# Altium schematic sheet Sheet4.SchDoc(Sheet4)
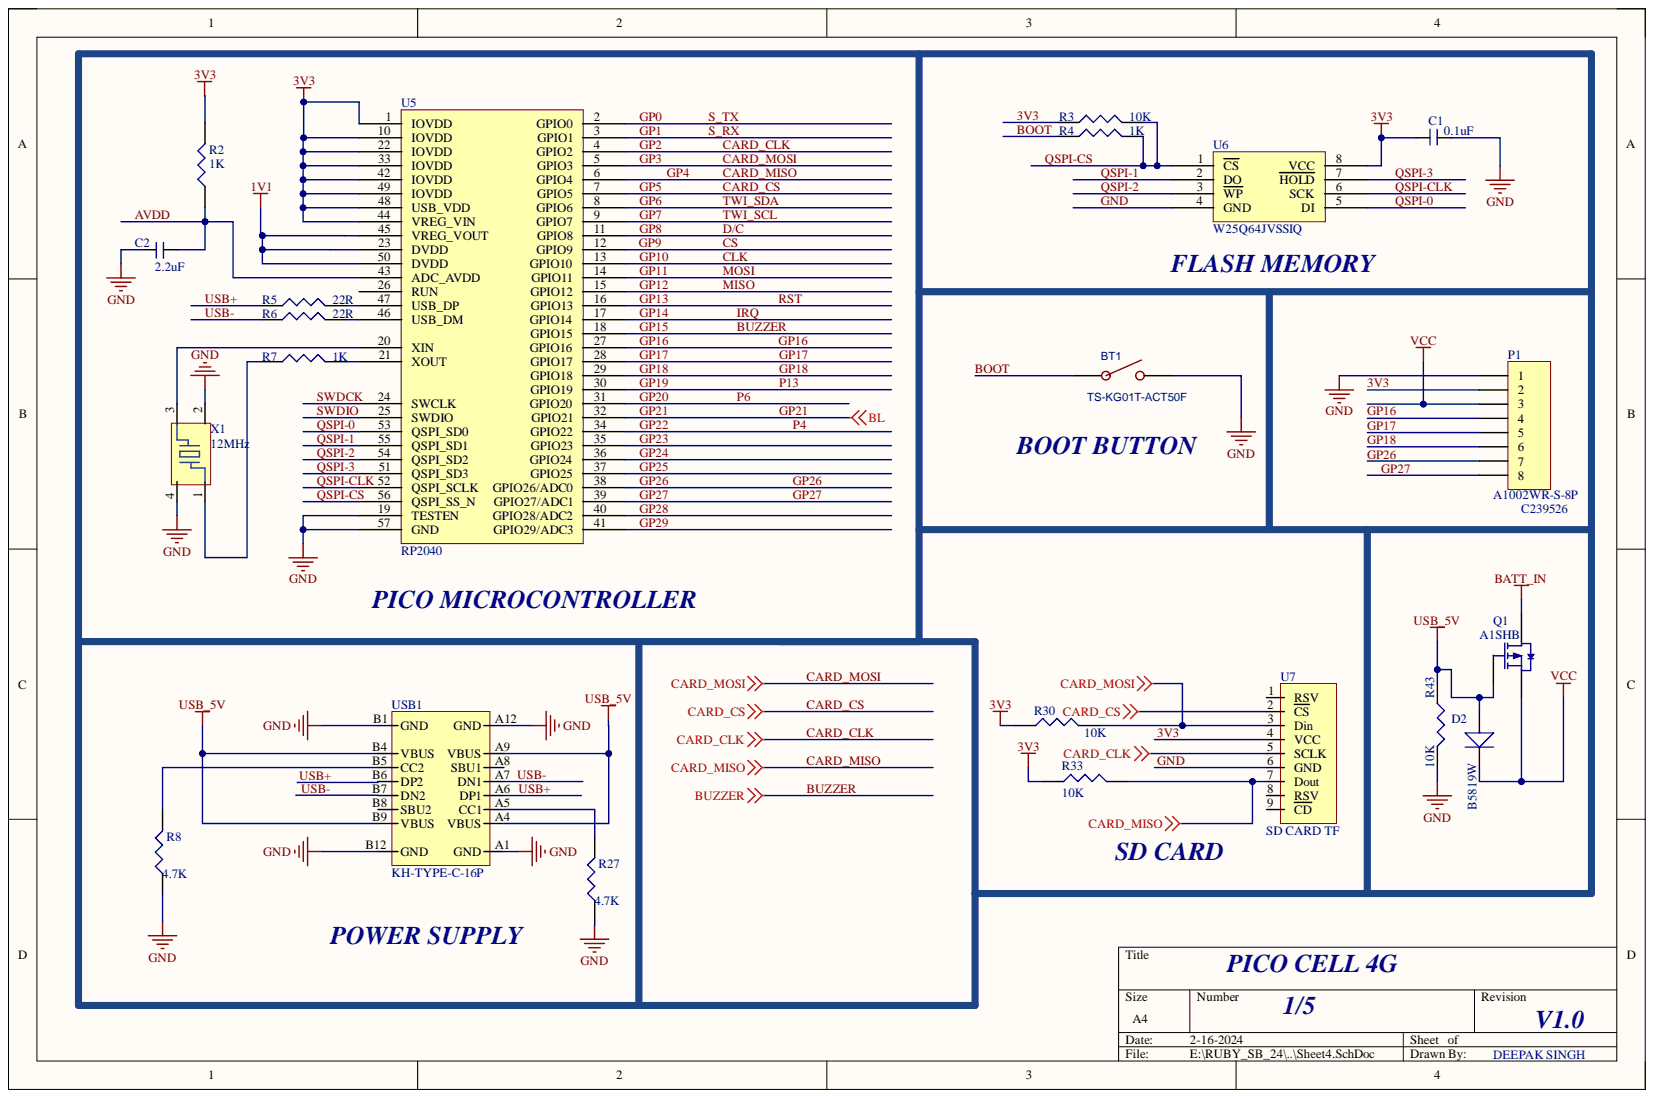
[diagram p0: Sheet4.SchDoc]

## Parts
BT1  TS-KG01T-ACT50F  [SW_2P SMD]
C1  0.1uF  [C_0603]
C2  2.2uF  [C_0603]
D2  B5819W  [SOD-123]
P1  A1002WR-S-8P  [SH 1X8P 1MM]
Q1  A1SHB  [SOT23-3]
R2  1K  [R_0603]
R3  10K  [R_0603]
R4  1K  [R_0603]
R5  22R  [R_0603]
R6  22R  [R_0603]
R7  1K  [R_0603]
R8  4.7K  [R_0603]
R27  4.7K  [R_0603]
R30  10K  [R_0603]
R33  10K  [R_0603]
R43  10K  [R_0603]
U5  RP2040  [QFN56]
U6  W25Q64JVSSIQ  [SOIC-8]
U7  SD CARD TF  [micro sd card]
USB1  KH-TYPE-C-16P  [USB TYPE C]
X1  12MHz  [crystal]

## Nets
1V1: U5.23(DVDD) U5.45(VREG_VOUT) U5.50(DVDD)
BATT_IN: Q1.3
USB_5V: D2.A Q1.1 R43.1 USB1.A4(VBUS) USB1.A9(VBUS) USB1.B4(VBUS) USB1.B9(VBUS)
VCC: D2.K P1.3 Q1.2
3V3: C1.2 P1.2 R2.2 R3.1 R30.1 R33.1 U5.1(IOVDD) U5.10(IOVDD) U5.22(IOVDD) U5.33(IOVDD) U5.42(IOVDD) U5.44(VREG_VIN) U5.48(USB_VDD) U5.49(IOVDD) U6.8(VCC) U7.4(VCC)
AVDD: C2.2 R2.1 U5.43(ADC_AVDD)
BOOT: BT1.1 R4.1
BUZZER: U5.18(GPIO15)
CARD_CLK: U5.4(GPIO2) U7.5(SCLK)
CARD_CS: U5.7(GPIO5) U7.2(CS)
CARD_MISO: R33.2 U5.6(GPIO4) U7.7(Dout)
CARD_MOSI: R30.2 U5.5(GPIO3) U7.3(Din)
CLK: U5.13(GPIO10)
CS: U5.12(GPIO9)
D/C: U5.11(GPIO8)
GND: BT1.2 C1.1 C2.1 P1.1 R8.1 R27.1 R43.2 U5.19(TESTEN) U5.57(GND) U6.4(GND) U7.6(GND) USB1.A1(GND) USB1.A12(GND) USB1.B1(GND) USB1.B12(GND) X1.2 X1.4
GP0: U5.2(GPIO0)
GP1: U5.3(GPIO1)
GP6: U5.8(GPIO6)
GP7: U5.9(GPIO7)
GP11: U5.14(GPIO11)
GP12: U5.15(GPIO12)
GP13: U5.16(GPIO13)
GP14: U5.17(GPIO14)
GP16: P1.4 U5.27(GPIO16)
GP17: P1.5 U5.28(GPIO17)
GP18: P1.6 U5.29(GPIO18)
GP19: U5.30(GPIO19)
GP20: U5.31(GPIO20)
GP21: U5.32(GPIO21)
GP22: U5.34(GPIO22)
GP23: U5.35(GPIO23)
GP24: U5.36(GPIO24)
GP25: U5.37(GPIO25)
GP26: P1.7 U5.38(GPIO26/ADC0)
GP27: P1.8 U5.39(GPIO27/ADC1)
GP28: U5.40(GPIO28/ADC2)
GP29: U5.41(GPIO29/ADC3)
QSPI-0: U5.53(QSPI_SD0) U6.5(DI)
QSPI-1: U5.55(QSPI_SD1) U6.2(DO)
QSPI-2: U5.54(QSPI_SD2) U6.3(WP)
QSPI-3: U5.51(QSPI_SD3) U6.7(HOLD)
QSPI-CLK: U5.52(QSPI_SCLK) U6.6(SCK)
QSPI-CS: R3.2 R4.2 U5.56(QSPI_SS_N) U6.1(CS)
SWDCK: U5.24(SWCLK)
SWDIO: U5.25(SWDIO)
USB+: R5.1 USB1.A6(DP1) USB1.B6(DP2)
USB-: R6.1 USB1.A7(DN1) USB1.B7(DN2)
NetR5_2: R5.2 U5.47(USB_DP)
NetR6_2: R6.2 U5.46(USB_DM)
NetR7_1: R7.1 X1.1
NetR7_2: R7.2 U5.21(XOUT)
NetR8_2: R8.2 USB1.B5(CC2)
NetR27_2: R27.2 USB1.A5(CC1)
NetU5_20: U5.20(XIN) X1.3

Not connected: U5 26(RUN); U7 1(RSV) 8(RSV) 9(CD); USB1 A8(SBU1) B8(SBU2)

## Library data of the parts
BT1 = JT1185SAEM: BOM_Manufacturer: NAMAE(韩国南涯); Contributor: LCSC; BOM_JLCPCB Part Class: Extended Part; BOM_Manufacturer Part: JT1185SAEM; BOM_Supplier: LCSC; BOM_Supplier Part: C465068; Component Kind: Standard; spicePre: S; spiceSymbolName: JT1185SAEM
C1 C2 = CAP_NONPOLAR: Component Kind: Standard; Library Name: dxp_pasl_lib.IntLib
D2 = DIODE: Component Kind: Standard; Library Name: dxp_pasl_lib.IntLib
P1 = Header 8: Header, 8-Pin; Component Kind: Standard; LatestRevisionDate: 17-Jul-2002; LatestRevisionNote: Re-released for DXP Platform.; Library Name: Miscellaneous Connectors.IntLib; Signal Integrity: Connector; Publisher: Altium Limited
Q1 = BSS84: 50V 130mA 250mW 10Ω 10V,130mA 2V 1mA P Channel SOT-23(SOT-23-3) MOSFETs ROHS #C493579; PackageDocument: 1995/1996; CATEGORY: MOSFET; Component Kind: Standard; LatestRevisionDate: 17-Jul-2002; LatestRevisionNote: Re-released for DXP Platform.; PackageReference: E3; Published: 8-Jun-2000; Library Name: dxp_pasl_lib.IntLib; Publisher: Altium Limited
R2-R8 R27 R30 R33 R43 = RES: Component Kind: Standard; Library Name: dxp_pasl_lib.IntLib
U5 = RP2040: A MICROCONTROLLER BY RPI; Component Kind: Standard; Library Name: dxp_pasl_lib.IntLib
U6 = W25Q128FV: FLASH - NOR Memory IC SPI - Quad I/O, QPI 104MHz 8-SOIC; Component Kind: Standard; Library Name: dxp_pasl_lib.IntLib
U7 = SD CARD TF: Micro SD Memory Card Socket; Component Kind: Standard; Library Name: dxp_pasl_lib.IntLib
USB1 = USB TYPE-C KH-TYPE-C-16P: 3A 1 Surface Mount 16 Female -40?~+85? Type-C SMD USB Connectors ROHS #  C709357; Component Kind: Standard; ComponentLink2Description: New link; ComponentLink2URL: https://lcsc.com/product-detail/USB-Connectors_Shenzhen-Kinghelm-Elec-KH-TYPE-C-16P_C709357.html; Supplier 1: LCSC; Supplier Part Number 1: C165948; Library Name: dxp_pasl_lib.IntLib
X1 = CRYSTAL_OSCILATOR: 12MHz ±10ppm 12pF 80Ω SMD-3225_4P Crystals RoHS #C70565; Component Kind: Standard; Library Name: dxp_pasl_lib.IntLib
